# Altium schematic sheet ToolSub.SchDoc
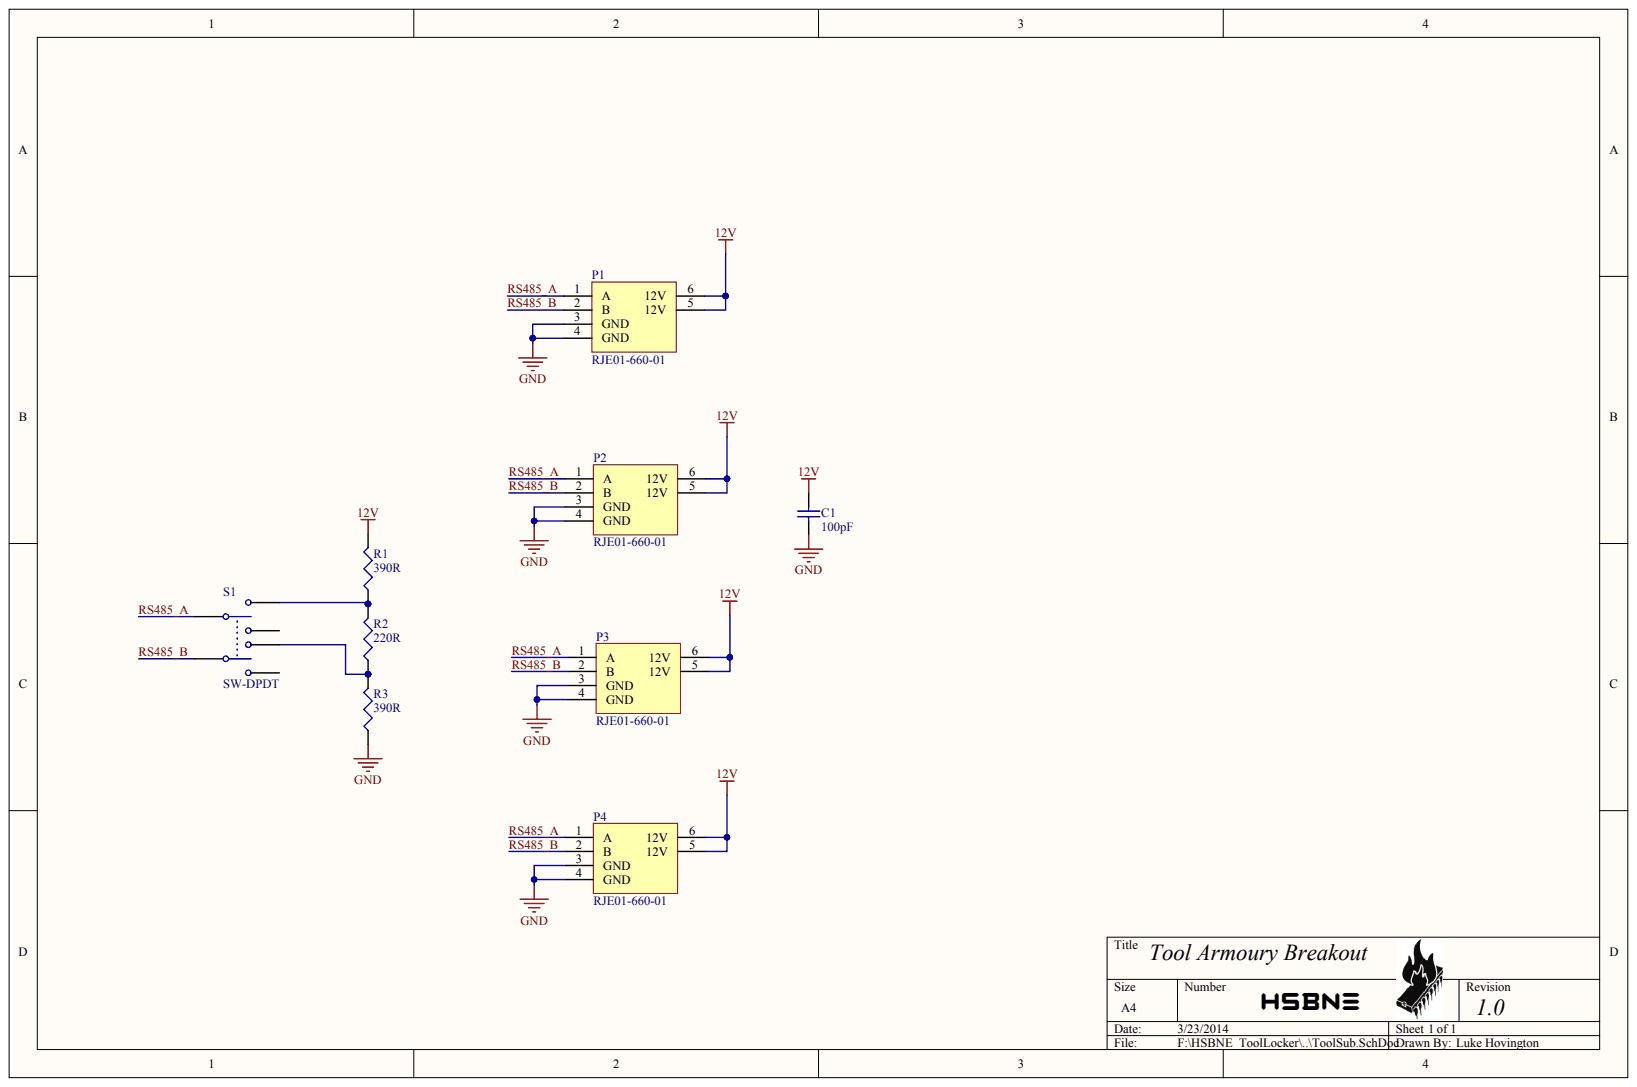
[diagram p0: ToolSub.SchDoc]

## Parts
C1  100pF
P1  RJE01-660-01
P2  RJE01-660-01
P3  RJE01-660-01
P4  RJE01-660-01
R1  390R
R2  220R
R3  390R
S1  SW-DPDT

## Nets
12V: C1.2 P1.5(12V) P1.6(12V) P2.5(12V) P2.6(12V) P3.5(12V) P3.6(12V) P4.5(12V) P4.6(12V) R1.2
GND: C1.1 P1.3(GND) P1.4(GND) P2.3(GND) P2.4(GND) P3.3(GND) P3.4(GND) P4.3(GND) P4.4(GND) R3.1
RS485_A: P1.1(A) P2.1(A) P3.1(A) P4.1(A) S1.2
RS485_B: P1.2(B) P2.2(B) P3.2(B) P4.2(B) S1.5
NetR1_1: R1.1 R2.2 S1.1
NetR2_1: R2.1 R3.2 S1.6

Not connected: S1 3 4

## Library data of the parts
C1 P1-P4 R1-R3 S1 = (no symbol name)
  C1: Published: 8-Jun-2000; LatestRevisionDate: 29-May-2009; LatestRevisionNote: IPC-7351 Footprint Added.; PackageReference: C1206; PackageDescription: Chip Capacitor; Value: 100pF; Supplier 1: Digi-Key; Supplier 2: Mouser; Supplier Part Number 1: 311-1161-1-ND; Supplier Part Number 2: 581-12065A101J
  P1-P4: Supplier 1: Mouser; Supplier 2: Farnell; Supplier Part Number 1: 523-RJE01-660-01; Supplier Part Number 2: 2095366; Supplier 3: Digi-Key; Supplier Part Number 3: RJE0166001-ND
  R1 R3: Published: 8-Jun-2000; LatestRevisionDate: 29-May-2009; LatestRevisionNote: IPC-7351 Footprint Added.; PackageReference: J1-0603; PackageDescription: Chip Resistor; Value: 390R; Supplier 1: Digi-Key; Supplier Part Number 1: RMCF1206JT390RCT-ND
  R2: Published: 8-Jun-2000; LatestRevisionDate: 29-May-2009; LatestRevisionNote: IPC-7351 Footprint Added.; PackageReference: J1-0603; PackageDescription: Chip Resistor; Value: 220R; Supplier 1: Digi-Key; Supplier Part Number 1: RMCF1206JT220RCT-ND
  S1: Published: 24-Mar-1999; LatestRevisionDate: 17-Jul-2002; LatestRevisionNote: Re-released for DXP Platform.; PackageReference: DPDT-6; PackageDescription: Switch; 6 Leads; Supplier 1: Digi-Key; Supplier 2: Mouser; Supplier Part Number 1: 401-2000-ND; Supplier Part Number 2: 611-JS202011AQN
  C1 R1-R3 S1: Publisher: Altium Limited
